# Altium schematic sheet FETs.SchDoc
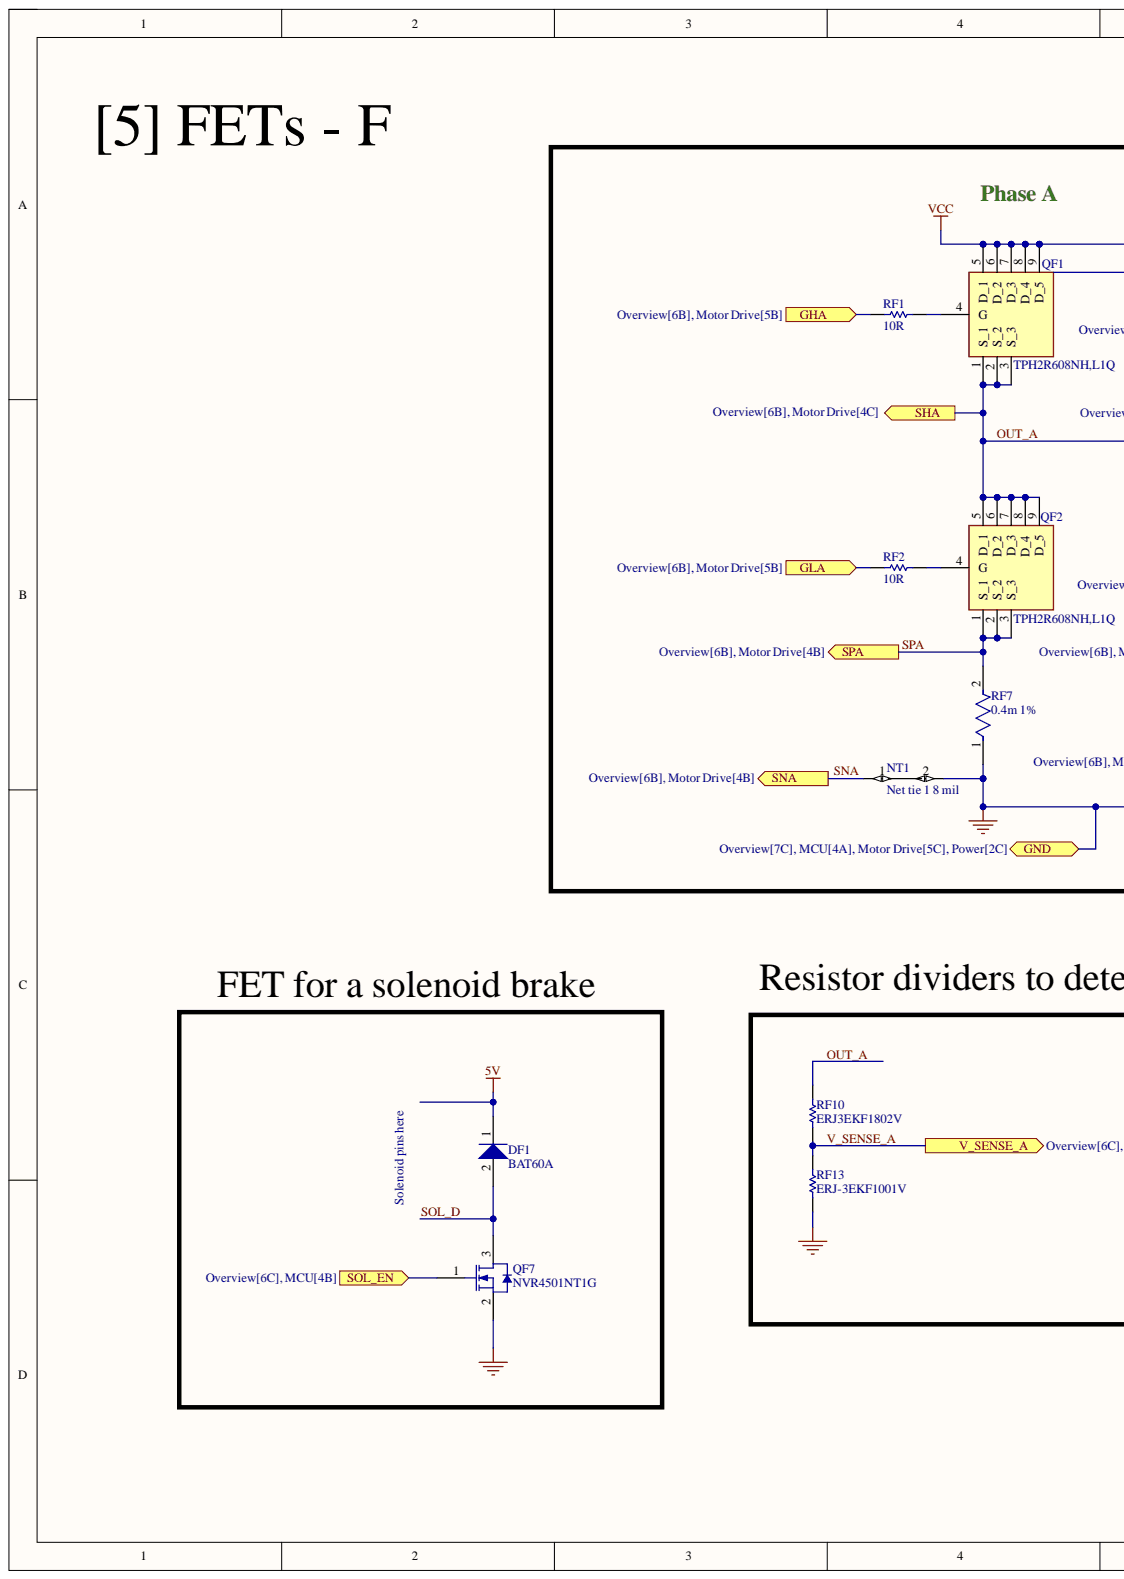
[diagram p0: FETs.SchDoc, left]
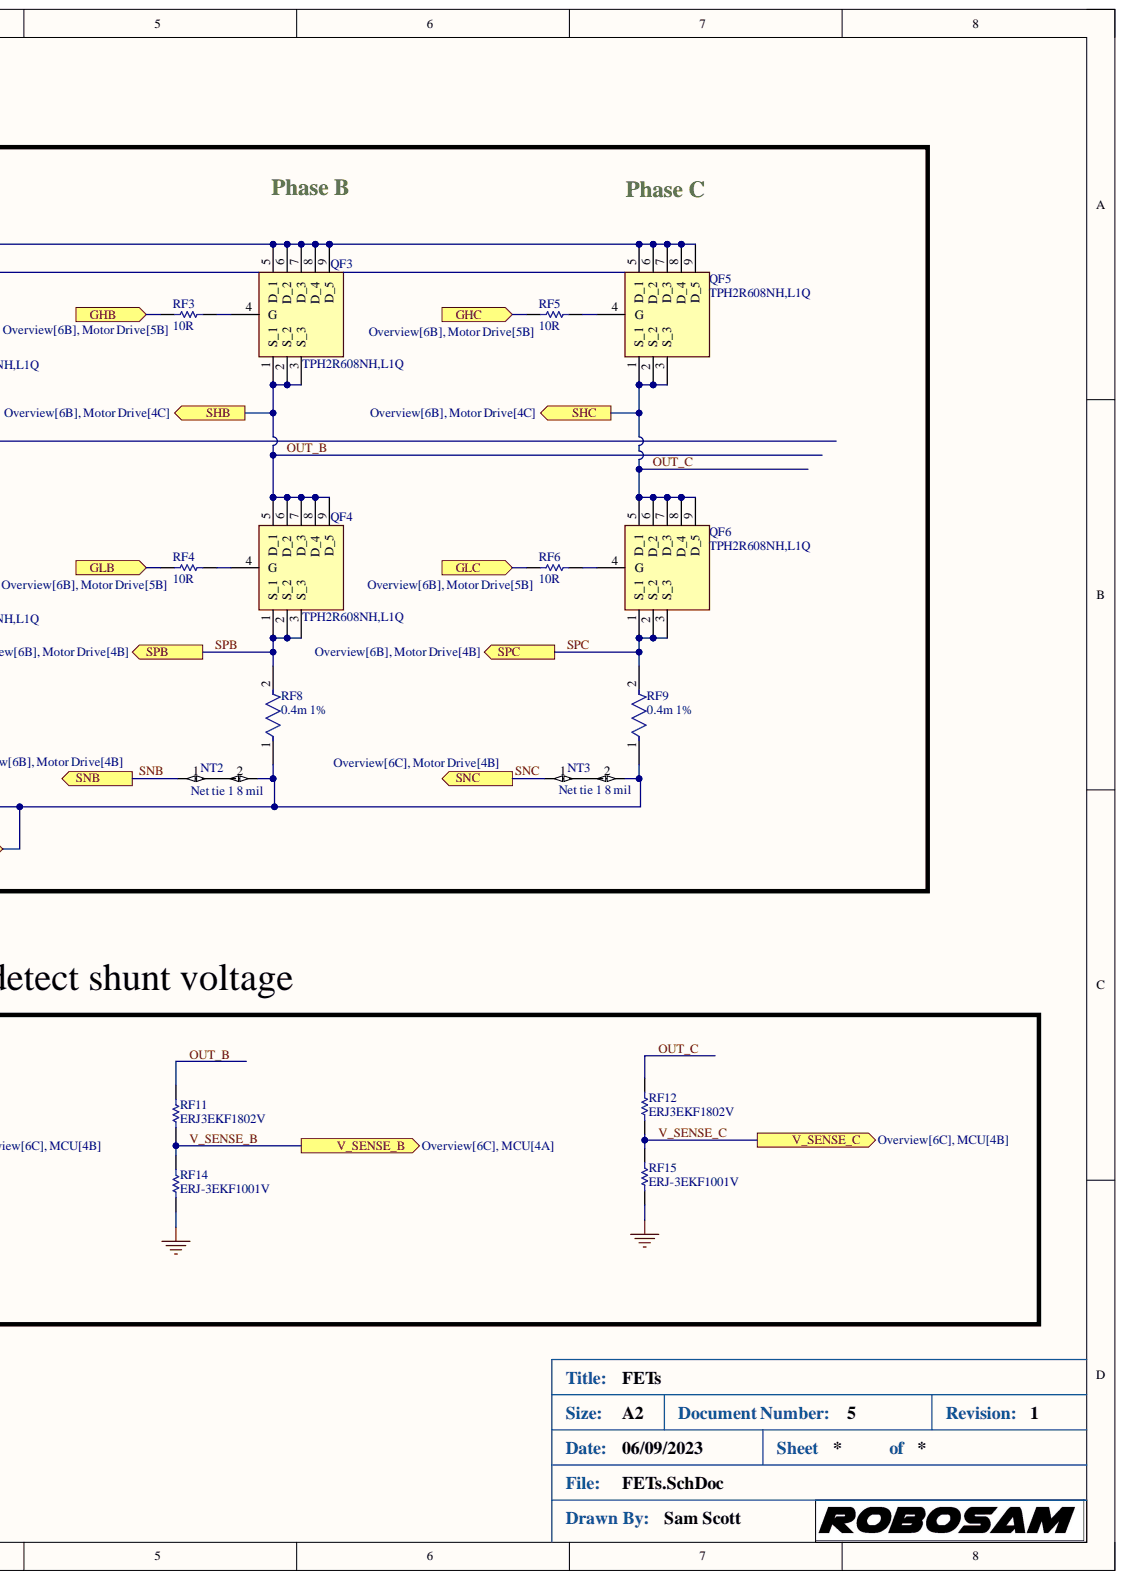
[diagram p1: FETs.SchDoc, right]

## Parts
DF1  BAT60A  [INF-SOD323_V]
NT1  Net tie 1 8 mil  [Net Tie 1 8mil]
NT2  Net tie 1 8 mil  [Net Tie 1 8mil]
NT3  Net tie 1 8 mil  [Net Tie 1 8mil]
QF1  TPH2R608NH,L1Q  [TPH2R608NHL1Q]
QF2  TPH2R608NH,L1Q  [TPH2R608NHL1Q]
QF3  TPH2R608NH,L1Q  [TPH2R608NHL1Q]
QF4  TPH2R608NH,L1Q  [TPH2R608NHL1Q]
QF5  TPH2R608NH,L1Q  [TPH2R608NHL1Q]
QF6  TPH2R608NH,L1Q  [TPH2R608NHL1Q]
QF7  NVR4501NT1G  [ONSC-SOT-23-3-318-08_V]
RF1  10R  [RESC1608X55X30NL20T10]
RF2  10R  [RESC1608X55X30NL20T10]
RF3  10R  [RESC1608X55X30NL20T10]
RF4  10R  [RESC1608X55X30NL20T10]
RF5  10R  [RESC1608X55X30NL20T10]
RF6  10R  [RESC1608X55X30NL20T10]
RF7  0.4m 1%  Tolerance: 1%  [FP-PU3921-0U4-MFG]
RF8  0.4m 1%  Tolerance: 1%  [FP-PU3921-0U4-MFG]
RF9  0.4m 1%  Tolerance: 1%  [FP-PU3921-0U4-MFG]
RF10  ERJ3EKF1802V  [RESC1608X55X30LL15T15]
RF11  ERJ3EKF1802V  [RESC1608X55X30LL15T15]
RF12  ERJ3EKF1802V  [RESC1608X55X30LL15T15]
RF13  ERJ-3EKF1001V  [RESC1608X55X30LL15T15]
RF14  ERJ-3EKF1001V  [RESC1608X55X30LL15T15]
RF15  ERJ-3EKF1001V  [RESC1608X55X30LL15T15]

## Ports
GHA, GHB, GHC, GLA, GLB, GLC, GND, SHA, SHB, SHC, SNA, SNB, SNC, SOL_EN, SPA, SPB, SPC, V_SENSE_A, V_SENSE_B, V_SENSE_C

## Nets
5V: DF1.1
VCC: QF1.5(D_1) QF1.6(D_2) QF1.7(D_3) QF1.8(D_4) QF1.9(D_5) QF3.5(D_1) QF3.6(D_2) QF3.7(D_3) QF3.8(D_4) QF3.9(D_5) QF5.5(D_1) QF5.6(D_2) QF5.7(D_3) QF5.8(D_4) QF5.9(D_5)
GHA: RF1.1 ; port GHA
GHB: RF3.1 ; port GHB
GHC: RF5.1 ; port GHC
GLA: RF2.1 ; port GLA
GLB: RF4.1 ; port GLB
GLC: RF6.1 ; port GLC
GND: NT1.2 NT2.2 NT3.2 QF7.2 RF7.1 RF8.1 RF9.1 RF13.1 RF14.1 RF15.1 ; port GND
OUT_A: QF1.1(S_1) QF1.2(S_2) QF1.3(S_3) QF2.5(D_1) QF2.6(D_2) QF2.7(D_3) QF2.8(D_4) QF2.9(D_5) RF10.2 ; port SHA
OUT_B: QF3.1(S_1) QF3.2(S_2) QF3.3(S_3) QF4.5(D_1) QF4.6(D_2) QF4.7(D_3) QF4.8(D_4) QF4.9(D_5) RF11.2 ; port SHB
OUT_C: QF5.1(S_1) QF5.2(S_2) QF5.3(S_3) QF6.5(D_1) QF6.6(D_2) QF6.7(D_3) QF6.8(D_4) QF6.9(D_5) RF12.2 ; port SHC
SNA: NT1.1 ; port SNA
SNB: NT2.1 ; port SNB
SNC: NT3.1 ; port SNC
SOL_D: DF1.2 QF7.3
SOL_EN: QF7.1 ; port SOL_EN
SPA: QF2.1(S_1) QF2.2(S_2) QF2.3(S_3) RF7.2 ; port SPA
SPB: QF4.1(S_1) QF4.2(S_2) QF4.3(S_3) RF8.2 ; port SPB
SPC: QF6.1(S_1) QF6.2(S_2) QF6.3(S_3) RF9.2 ; port SPC
V_SENSE_A: RF10.1 RF13.2 ; port V_SENSE_A
V_SENSE_B: RF11.1 RF14.2 ; port V_SENSE_B
V_SENSE_C: RF12.1 RF15.2 ; port V_SENSE_C
NetQF1_4: QF1.4(G) RF1.2
NetQF2_4: QF2.4(G) RF2.2
NetQF3_4: QF3.4(G) RF3.2
NetQF4_4: QF4.4(G) RF4.2
NetQF5_4: QF5.4(G) RF5.2
NetQF6_4: QF6.4(G) RF6.2

## Library data of the parts
DF1 = CMP-1183-00008-2: Silicon Schottky Diode, -55 to 85 degC, SOD323, Reel, Green; Manufacturer: Infineon; Configuration: Single; CT (max): 35Â pF; Green: TRUE; IF (max): 3,000Â mA; VF (max): 370Â mV; VR (max): 10Â V; Component Kind: Standard; ComponentLink1Description: Manufacturer URL; ComponentLink1URL: http://www.infineon.com/; ComponentLink2Description: Datasheet; ComponentLink2URL: http://www.infineon.com/dgdl/bat60aseries.pdf?folderId=db3a304313d846880113def5812204a1&fileId=db3a304313d846880113def70c9304a9; ComponentLink3Description: Package Specification; ComponentLink3URL: http://www.infineon.com/dgdl/SOT323-Package_Overview.pdf?folderId=db3a30431936bc4b0119533e1dcb3c92&fileId=db3a30431936bc4b011953495f283cb1; Library Name: Altium Content Vault; Mounting Technology: Surface Mount; PackageDescription: 3-Pin Small Outline Transistor Package, 1.25 x 2 mm Body, 0.65 mm Pitch; PackageReference: SOT323; Packing: Reel; PartNumber: BAT60A
NT1-NT3 = CMP-00048-6: For tying nets together; Component Kind: Net Tie; Library Name: TeamSunride
QF1-QF6 = TPH2R608NH,L1Q: MOSFET (N-Channel); Manufacturer_Name: Toshiba; Arrow Part Number: TPH2R608NH,L1Q; Arrow Price/Stock: https://www.arrow.com/en/products/tph2r608nhl1q/toshiba?region=nac; Component Kind: Standard; Datasheet Link: https://toshiba.semicon-storage.com/info/docget.jsp?did=15733&prodName=TPH2R608NH; Height: 1mm; Library Name: SamacSys.SchLib; Manufacturer_Part_Number: TPH2R608NH,L1Q; Mouser Part Number: 757-TPH2R608NHL1Q; Mouser Price/Stock: https://www.mouser.co.uk/ProductDetail/Toshiba/TPH2R608NHL1Q?qs=Ey7%2FXF42M3fcGoow2nEN5w%3D%3D
QF7 = CMP-1058-00733-1: Power MOSFET, 20 V, 3.2 A, Single N-Channel, 3-Pin SOT-23, Pb-Free, Tape and Reel; Manufacturer: On Semiconductor; Channel Polarity: N Channel; Ciss Typ (pF): 200; Coss Typ (pF): 80; Crss Typ (pF): 50; PD Max (W): 1.25; Qrr Typ (nC): 3; V(BR)DSS Min (V): 20; VGS Max (V): 12; VGS(th) Max (V): 1.2; Component Kind: Standard; ComponentLink1Description: Manufacturer URL; ComponentLink1URL: http://www.onsemi.com/; ComponentLink2Description: Datasheet; ComponentLink2URL: http://www.onsemi.cn/pub_link/Collateral/NTR4501N-D.PDF; ComponentLink3Description: Package Specification; ComponentLink3URL: http://www.onsemi.cn/pub_link/Collateral/318-08.PDF; Configuration: Single; DatasheetVersion: Rev. 13; Library Name: Altium Content Vault; Mounting Technology: Surface Mount; Package Type: SOT-23-3; PackageDescription: 3-Pin Small Outline Transistor, Body 2.92 x 1.3 mm, Pitch 0.95 mm; PackageReference: SOT-23-3-318-08; PackageVersion: Rev. AP, 11/2009; Packing: Tape and Reel; PartNumber: NVR4501NT1G; RoHS: Pb-Free
RF1-RF6 = CMP-2000-01115-1: Manufacturer: KOA Speer; Part Number: RK73H1JTTD10R0F; Component Kind: Standard; Library Name: Altium Content Vault
RF7-RF9 = CMP-03412-007056-1: METAL SHUNT RESISTOR 3921 1% 175; Manufacturer: Yageo; Manufacturer Part Number: PU3921FKNP50U4L; Automotive Grade: Grade 0; Power Rating: 5W; Resistance: 0.4mΩ; Temperature Coefficient: 175ppm/°C; Value: 0.4mΩ; Automotive: Yes; Category: Res; Component Kind: Standard; ComponentLink2Description: Datasheet; ComponentLink2URL: http://www.yageo.com/documents/recent/PYu-PU_51_RoHS_L_6.pdf; Composition: Metal Element; Device Class L1: Passive Components; Device Class L2: Resistors; Device Class L3: Chip SMD Resistors; Digikey Description: METAL SHUNT RESISTOR 3921 1% 175; Height: 1.81mm; Ipc Land Pattern Name: RESC1000520X155; Lead Free: Yes; Library Name: Altium Content Vault; Octopart: https://octopart.com/pu3921fknp50u4l-yageo-96774574; Package: PU3921-0U4; RoHS: TRUE; Series: PU; Temperature: -65°C to +170°C; Temperature Range High: +170°C; Temperature Range Low: -65°C
RF10-RF12 = CMP-1012-00650-3: Fixed Resistor, Metal Glaze/thick Film, 0.1W, 18000ohm, 75V, 1% +/-Tol, 100ppm/Cel, Surface Mount, 0603; Manufacturer: Panasonic; Component Kind: Standard; Library Name: Altium Content Vault; Part Number: ERJ3EKF1802V
RF13-RF15 = CMP-2000-00180-1: Manufacturer: Panasonic; Component Kind: Standard; Library Name: Altium Content Vault; Part Number: ERJ-3EKF1001V
